# Altium schematic sheet POWER.SchDoc
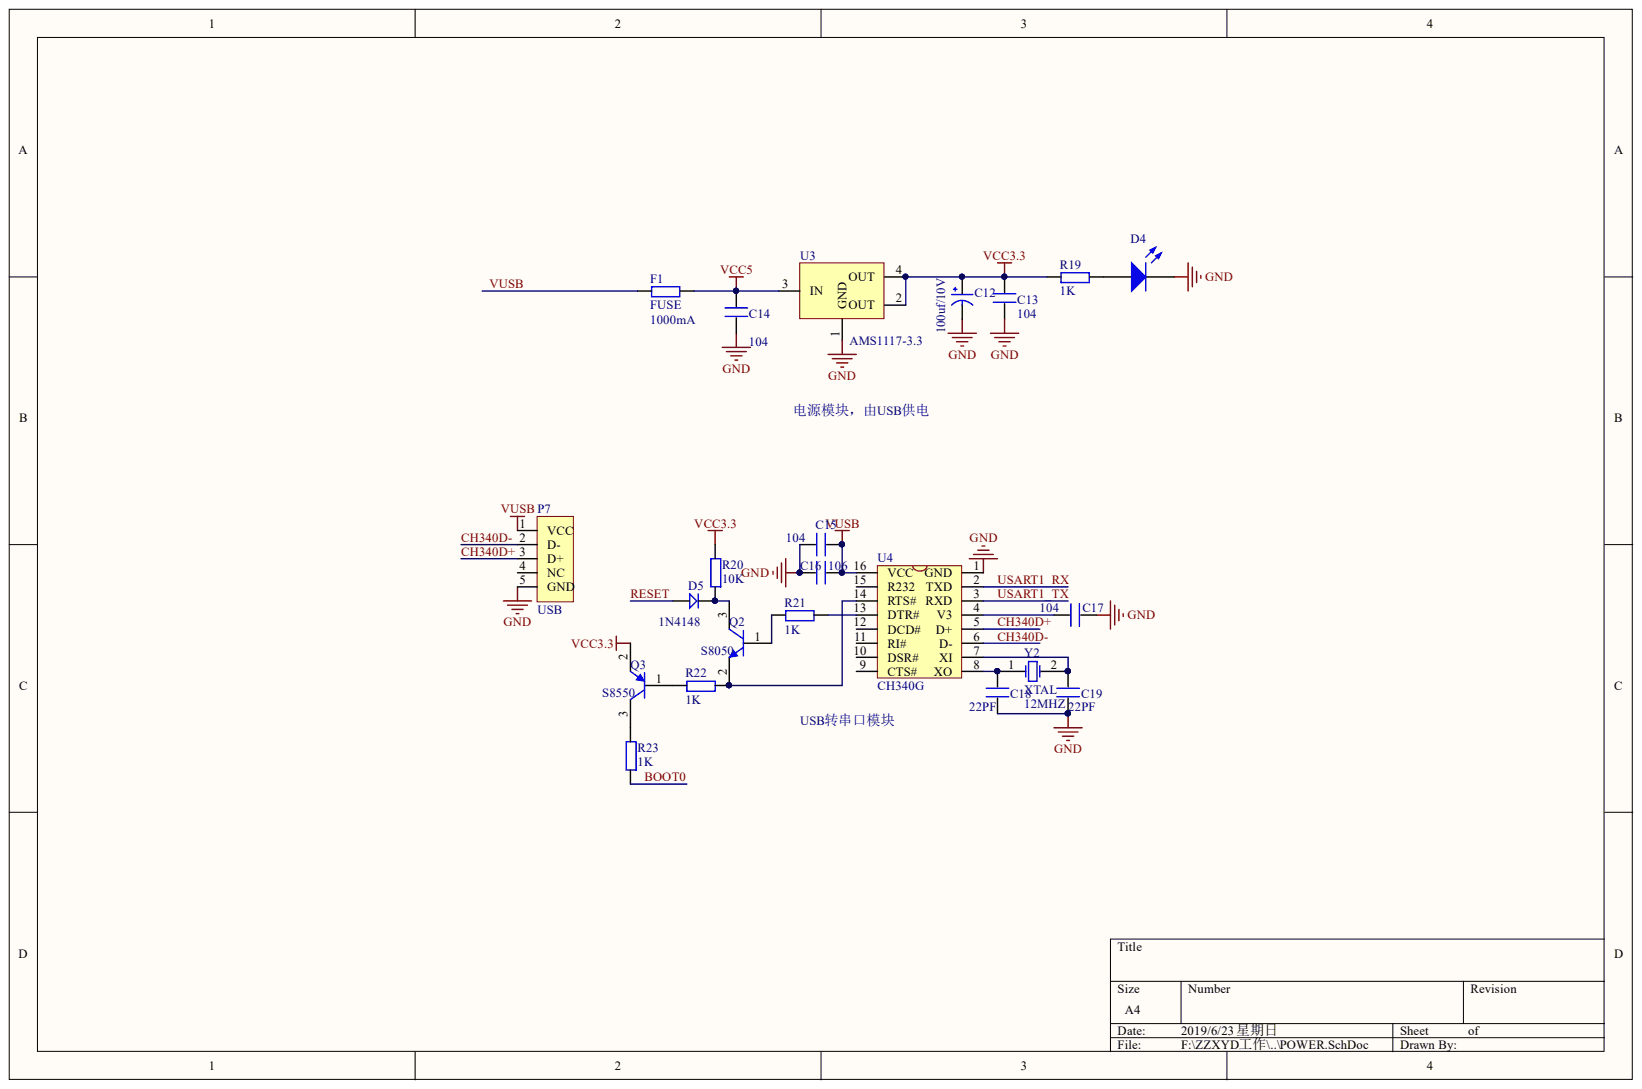
[diagram p0: POWER.SchDoc]

## Parts
C12  value 100uf/10V  [C 1206]  (hidden comment: CAP_POL)
C13  value 104  [0805C]  (hidden comment: CAP)
C14  C  value 104  [0805C]
C15  value 104  [0805C]  (hidden comment: CAP)
C16  value 106  [0805C]  (hidden comment: CAP)
C17  value 104  [0805C]  (hidden comment: CAP)
C18  value 22PF  [0805C]  (hidden comment: CAP)
C19  value 22PF  [0805C]  (hidden comment: CAP)
D4  LED0  [LED_0805]  (value not drawn)
D5  1N4148  [DO-213AA]
F1  FUSE  value 1000mA  [0805]
P7  USB  [Mirco-USB - 5P4脚]
Q2  S8050  [SOT-23]
Q3  S8550  [SOT-23]
R19  value 1K  [0805]  (hidden comment: RES)
R20  value 10K  [0805]  (hidden comment: RES)
R21  value 1K  [0805]  (hidden comment: RES)
R22  value 1K  [0805]  (hidden comment: RES)
R23  value 1K  [0805]  (hidden comment: RES)
U3  AMS1117-3.3  [SOT-223]
U4  CH340G  [SOP16]
Y2  XTAL  value 12MHZ  [HC-49S]

## Nets
GND: C12.2 C13.1 C14.1 C15.2 C16.2 C17.1 C18.2 C19.2 D4.2 P7.5(GND) U3.1(GND) U4.1(GND)
VCC3.3: C12.1 C13.2 Q3.2 R19.1 R20.2 U3.2(OUT) U3.4(OUT)
VCC5: C14.2 F1.2 U3.3(IN)
BOOT0: R23.1
CH340D+: P7.3(D+) U4.5(D+)
CH340D-: P7.2(D-) U4.6(D-)
RESET: D5.1
USART1_RX: U4.2(TXD)
USART1_TX: U4.3(RXD)
VUSB: C15.1 C16.1 F1.1 P7.1(VCC) U4.16(VCC)
NetC17_2: C17.2 U4.4(V3)
NetC18_1: C18.1 U4.8(XO) Y2.1
NetC19_1: C19.1 U4.7(XI) Y2.2
NetD4_1: D4.1 R19.2
NetD5_2: D5.2 Q2.3 R20.1
NetQ2_1: Q2.1 R21.1
NetQ2_2: Q2.2 R22.2 U4.14(RTS#)
NetQ3_1: Q3.1 R22.1
NetQ3_3: Q3.3 R23.2
NetR21_2: R21.2 U4.13(DTR#)

Not connected: P7 4(NC); U4 9(CTS#) 10(DSR#) 11(RI#) 12(DCD#) 15(R232)

## Library data of the parts
C14 = C: CAP; Component Kind: Standard; Library Name: 主控端最小系统.SCHLIB
D4 = LED: 发光二极管; Component Kind: Standard; LatestRevisionDate: 17-Jul-2002; LatestRevisionNote: Re-released for DXP Platform.; PackageDocument: Feb-2002; PackageReference: 3.2X1.6X1.1; Published: 8-Jun-2000; Simulation: LED2; Library Name: 主控端最小系统.SCHLIB; Publisher: Altium Limited
F1 = FUSE: 保险丝; Component Kind: Standard; Library Name: 主控端最小系统.SCHLIB
P7 = USB5: Component Kind: Standard; Library Name: 主控端最小系统.SCHLIB
Q2 = NPN: NPN三极管; Component Kind: Standard; Library Name: 主控端最小系统.SCHLIB
Q3 = PNP: PNP三极管; Code_JEDEC: TO-92A; Component Kind: Standard; ComponentLink1Description: Manufacturer Link; ComponentLink1URL: http://www.fairchildsemi.com/; ComponentLink2Description: Datasheet; ComponentLink2URL: http://www.fairchildsemi.com/ds/2N%2F2N3906.pdf; DatasheetDocument: 1997; LatestRevisionDate: 15-Jan-2003; LatestRevisionNote: PCB Footprint 'BCY-W3/D4.7' replaced; PackageDocument: Sep-1998; PackageReference: TO-92A; Published: 8-Jun-2000; Library Name: 主控端最小系统.SCHLIB; Publisher: Altium Limited
U4 = CH340G: USB转串口芯片; Component Kind: Standard; Library Name: 主控端最小系统.SCHLIB
Y2 = XTAL: 无源晶振; value: 12MHZ; Component Kind: Standard; LatestRevisionDate: 17-Jul-2002; LatestRevisionNote: Re-released for DXP Platform.; Published: 8-Jun-2000; Simulation: XTAL; Library Name: 主控端最小系统.SCHLIB; Publisher: Altium Limited
C12 = CAP_POL: Component Kind: Standard; Library Name: 主控端最小系统.SCHLIB
C13 C15-C19 = CAP: Component Kind: Standard; Description: CAP; Library Name: 主控端最小系统.SCHLIB
D5 = 1N4148: Component Kind: Standard; Library Name: 主控端最小系统.SCHLIB
R19-R23 = RES: Component Kind: Standard; Description: RES; Library Name: 主控端最小系统.SCHLIB
U3 = AMS1117-3.3: Component Kind: Standard; Library Name: 主控端最小系统.SCHLIB
